# Altium schematic sheet uController.SchDoc(uController)
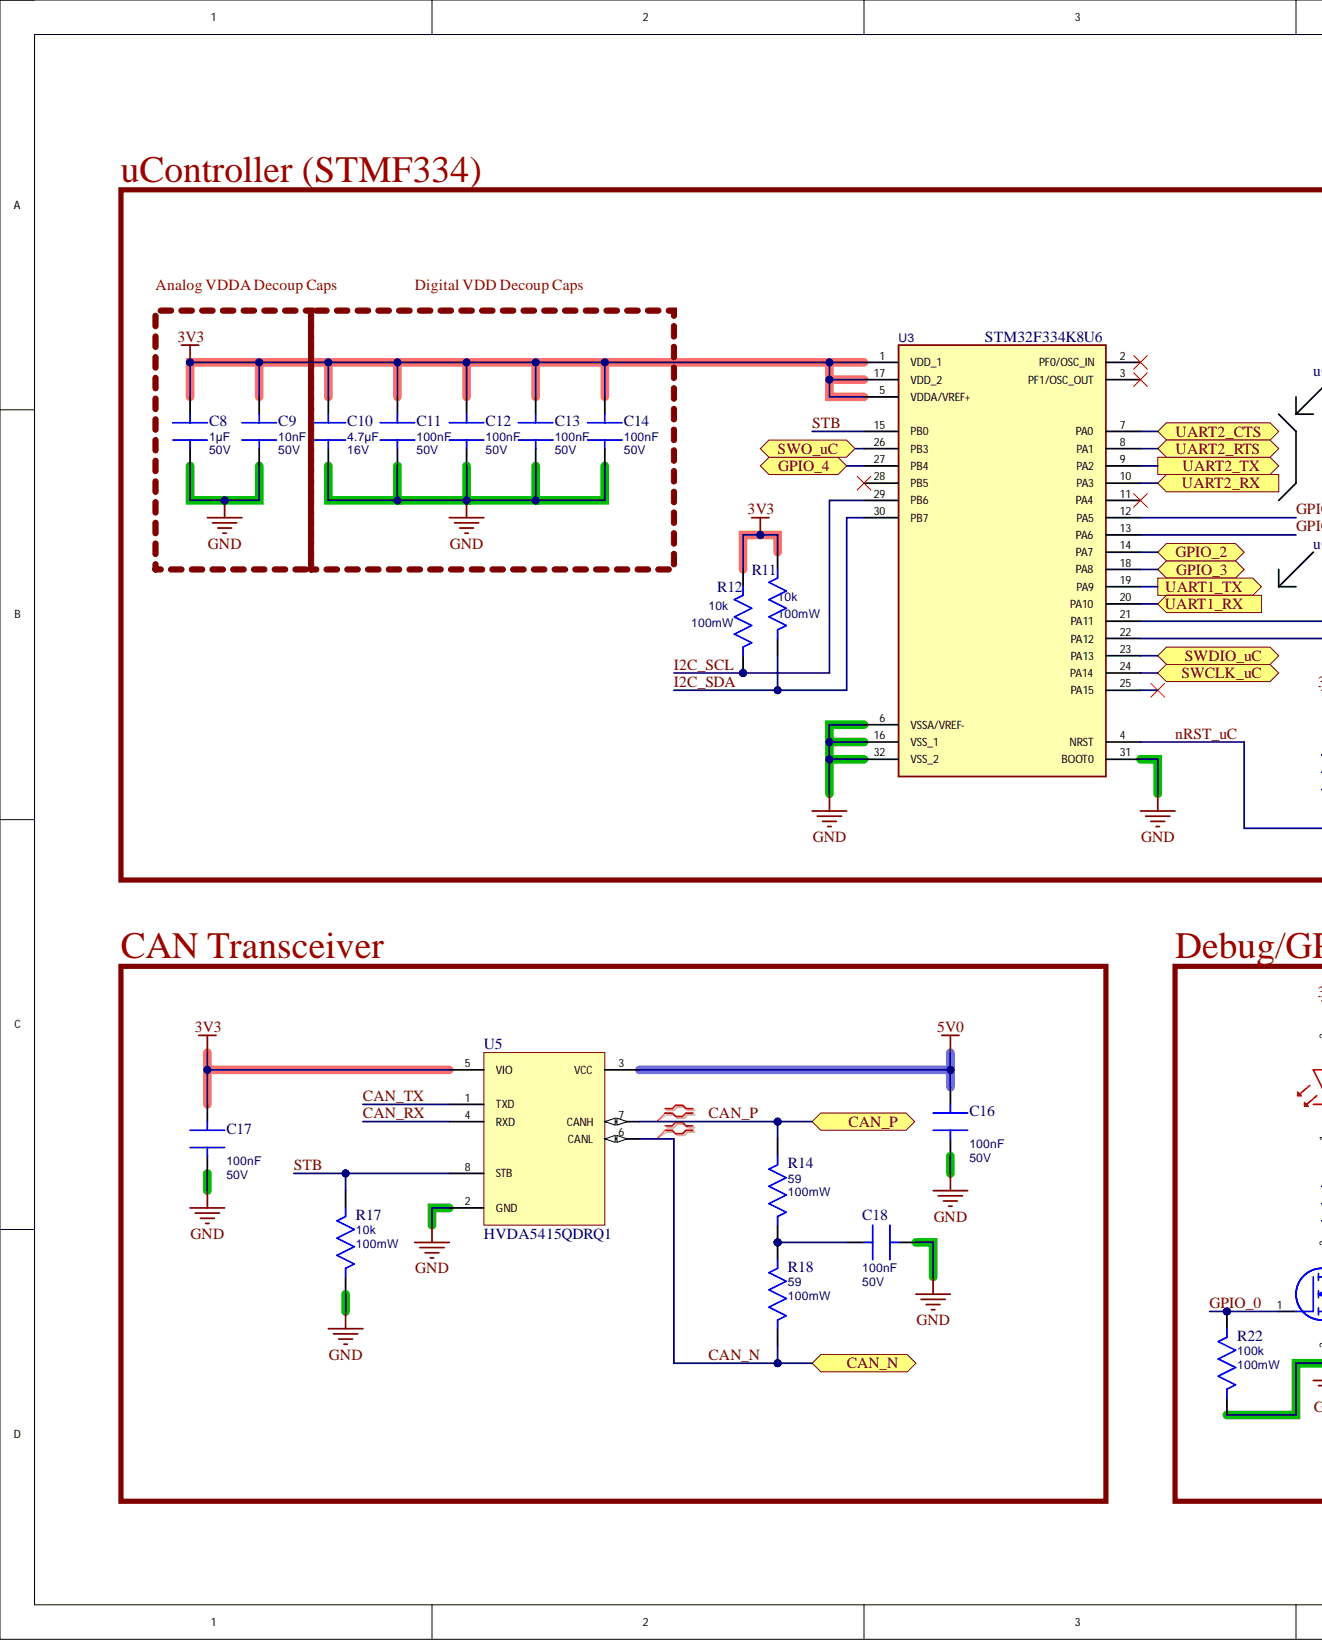
[diagram p0: uController.SchDoc, left]
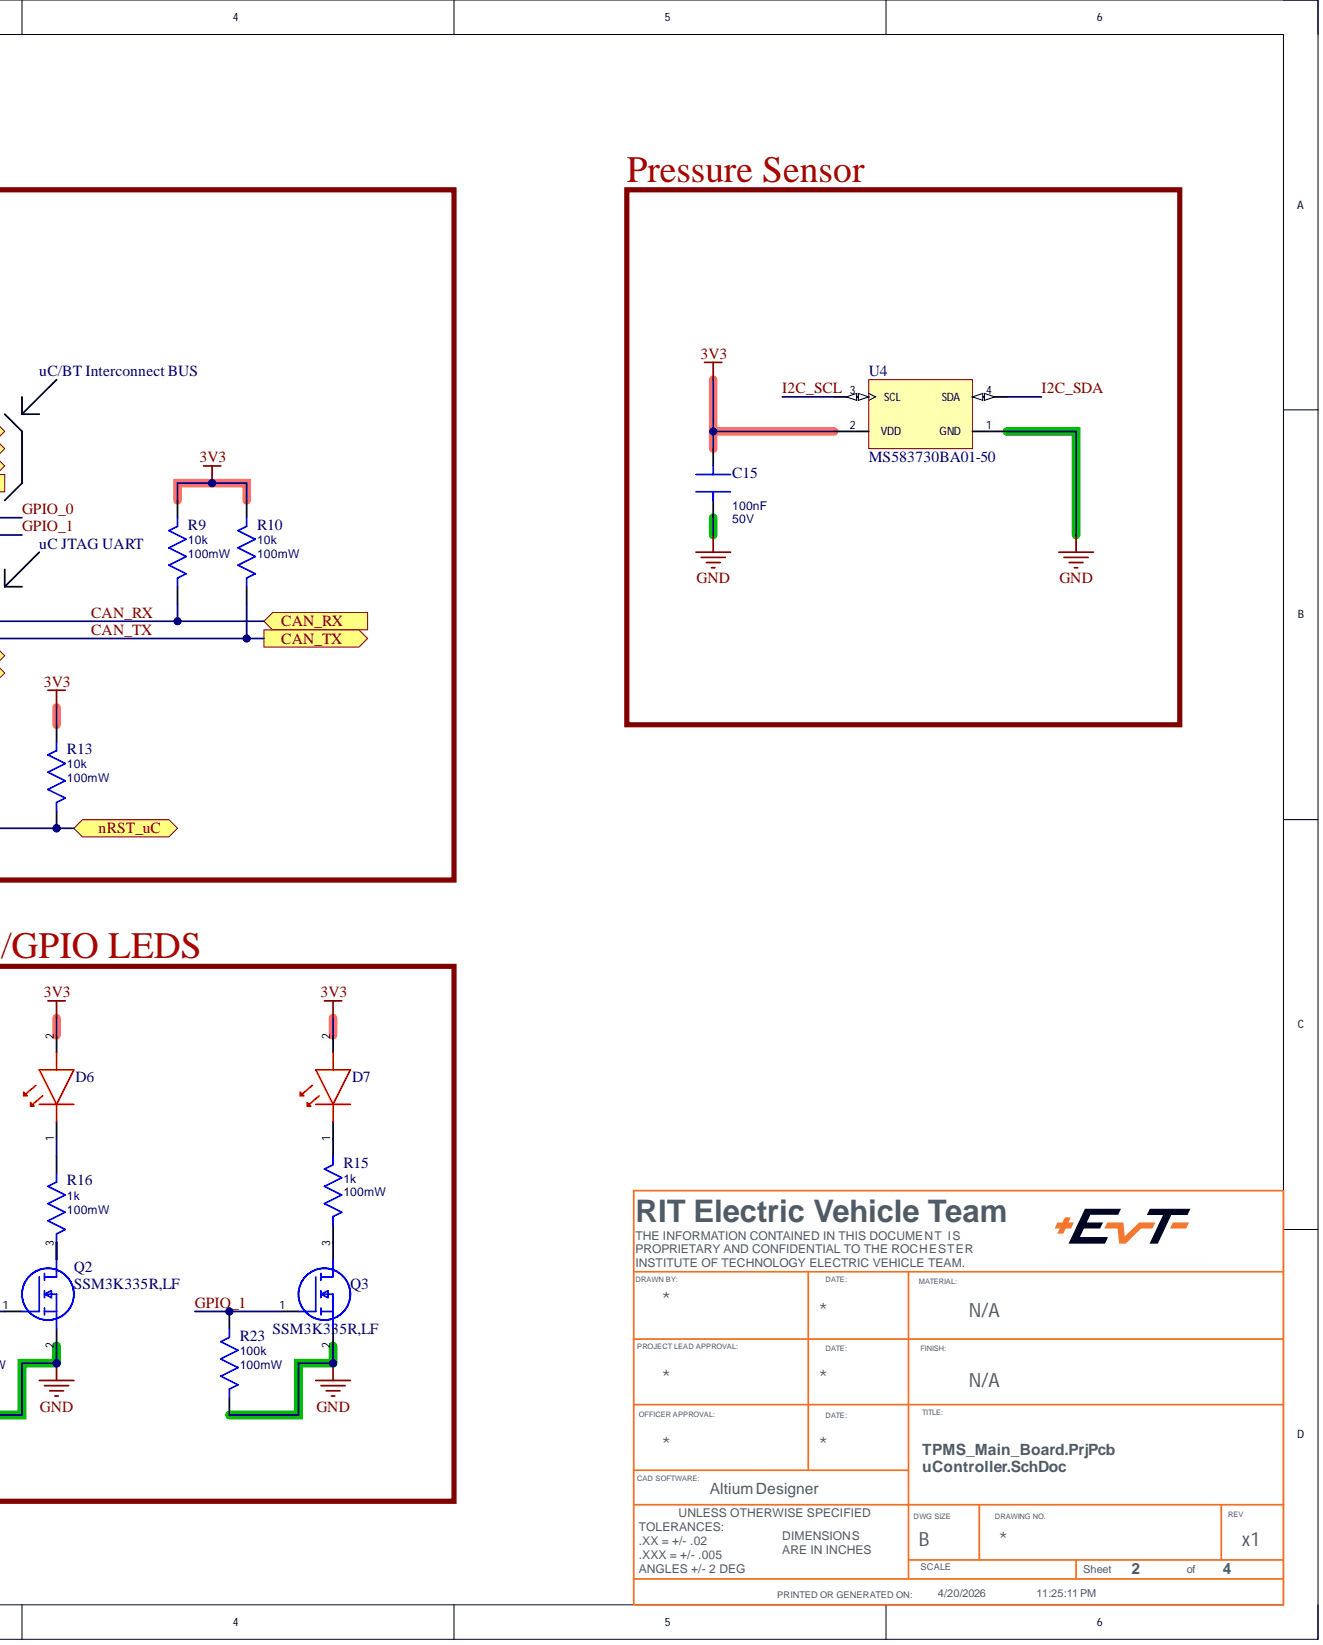
[diagram p1: uController.SchDoc, right]

## Parts
C8  Capacitance: 1µF  Voltage Rating: 50V  (hidden comment: CAP 1U0 50V X5R 10% 0603)
C9  Capacitance: 10nF  Voltage Rating: 50V  (hidden comment: CAP 10nF 50V X7R 10% 0603)
C10  Capacitance: 4.7µF  Voltage Rating: 16V  (hidden comment: CAP 4U7 16V X5R 10% 0603)
C11  Capacitance: 100nF  Voltage Rating: 50V  (hidden comment: CAP 100nF 50V X7R 10% 0603 CAP)
C12  Capacitance: 100nF  Voltage Rating: 50V  (hidden comment: CAP 100nF 50V X7R 10% 0603 CAP)
C13  Capacitance: 100nF  Voltage Rating: 50V  (hidden comment: CAP 100nF 50V X7R 10% 0603 CAP)
C14  Capacitance: 100nF  Voltage Rating: 50V  (hidden comment: CAP 100nF 50V X7R 10% 0603 CAP)
C15  Capacitance: 100nF  Voltage Rating: 50V  (hidden comment: CAP 100nF 50V X7R 10% 0603 CAP)
C16  Capacitance: 100nF  Voltage Rating: 50V  (hidden comment: CAP 100nF 50V X7R 10% 0603 CAP)
C17  Capacitance: 100nF  Voltage Rating: 50V  (hidden comment: CAP 100nF 50V X7R 10% 0603 CAP)
C18  Capacitance: 100nF  Voltage Rating: 50V  (hidden comment: CAP 100nF 50V X7R 10% 0603 CAP)
D6  RED 0603 LED  (value not drawn)
D7  RED 0603 LED  (value not drawn)
Q2  SSM3K335R,LF
Q3  SSM3K335R,LF
R9  Power Rating: 100mW  Resistance: 10k  (hidden comment: RES 10k0 100mW 1%)
R10  Power Rating: 100mW  Resistance: 10k  (hidden comment: RES 10k0 100mW 1%)
R11  Power Rating: 100mW  Resistance: 10k  (hidden comment: RES 10k 100m 0.1% 0603s)
R12  Power Rating: 100mW  Resistance: 10k  (hidden comment: RES 10k 100m 0.1% 0603s)
R13  Power Rating: 100mW  Resistance: 10k  (hidden comment: RES 10k0 100mW 1%)
R14  Power Rating: 100mW  (hidden comment: RES 59R 100mW 1% 0603)
R15  Power Rating: 100mW  Resistance: 1k  (hidden comment: RES 1k 100m 0.1% 0603)
R16  Power Rating: 100mW  Resistance: 1k  (hidden comment: RES 1k 100m 0.1% 0603)
R17  Power Rating: 100mW  Resistance: 10k  (hidden comment: RES 10k0 100mW 1%)
R18  Power Rating: 100mW  (hidden comment: RES 59R 100mW 1% 0603)
R22  Power Rating: 100mW  Resistance: 100k  (hidden comment: RES 1K0 100mW 1%)
R23  Power Rating: 100mW  Resistance: 100k  (hidden comment: RES 1K0 100mW 1%)
U3  STM32F334K8U6
U4  MS583730BA01-50
U5  HVDA5415QDRQ1

## Ports
CAN_N, CAN_P, CAN_RX, CAN_TX, GPIO_2, GPIO_3, GPIO_4, nRST_uC, SWCLK_uC, SWDIO_uC, SWO_uC, UART1_RX, UART1_TX, UART2_CTS, UART2_RTS, UART2_RX, UART2_TX

## Nets
3V3: C8.1 C9.1 C10.1 C11.1 C12.1 C13.1 C14.1 C15.1 C17.1 D6.2 D7.2 R9.2 R10.2 R11.1 R12.1 R13.2 U3.1(VDD_1) U3.5(VDDA/VREF+) U3.17(VDD_2) U4.2(VDD) U5.5(VIO)
5V0: C16.1 U5.3(VCC)
GND: C8.2 C9.2 C10.2 C11.2 C12.2 C13.2 C14.2 C15.2 C16.2 C17.2 C18.2 Q2.2 Q3.2 R17.2 R22.2 R23.2 U3.6(VSSA/VREF-) U3.16(VSS_1) U3.31(BOOT0) U3.32(VSS_2) U4.1(GND) U5.2(GND)
CAN_N: R18.2 U5.6(CANL) ; port CAN_N
CAN_P: R14.1 U5.7(CANH) ; port CAN_P
CAN_RX: R9.1 U3.21(PA11) U5.4(RXD) ; port CAN_RX
CAN_TX: R10.1 U3.22(PA12) U5.1(TXD) ; port CAN_TX
GPIO_0: Q2.1 R22.1 U3.12(PA5)
GPIO_1: Q3.1 R23.1 U3.13(PA6)
GPIO_2: U3.14(PA7) ; port GPIO_2
GPIO_3: U3.18(PA8) ; port GPIO_3
GPIO_4: U3.27(PB4) ; port GPIO_4
I2C_SCL: R12.2 U3.29(PB6) U4.3(SCL)
I2C_SDA: R11.2 U3.30(PB7) U4.4(SDA)
nRST_uC: R13.1 U3.4(NRST) ; port nRST_uC
STB: R17.1 U3.15(PB0) U5.8(STB)
SWCLK_uC: U3.24(PA14) ; port SWCLK_uC
SWDIO_uC: U3.23(PA13) ; port SWDIO_uC
SWO_uC: U3.26(PB3) ; port SWO_uC
UART1_RX: U3.20(PA10) ; port UART1_RX
UART1_TX: U3.19(PA9) ; port UART1_TX
UART2_CTS: U3.7(PA0) ; port UART2_CTS
UART2_RTS: U3.8(PA1) ; port UART2_RTS
UART2_RX: U3.10(PA3) ; port UART2_RX
UART2_TX: U3.9(PA2) ; port UART2_TX
NetC18_1: C18.1 R14.2 R18.1
NetD6_1: D6.1 R16.1
NetD7_1: D7.1 R15.1
NetQ2_3: Q2.3 R16.2
NetQ3_3: Q3.3 R15.2

Not connected: U3 2(PF0/OSC_IN) 3(PF1/OSC_OUT) 11(PA4) 25(PA15) 28(PB5)

## Library data of the parts
C8 = CMP-00418-4: 1uF 50V X5R ±10% 0603 Multilayer Ceramic Capacitors MLCC - SMD/SMT ROHS; MPN: CL10A105KB8NNNC; Polarized (Y/N): N; Component Kind: Standard; JLC Basic Part (Y/N): Y; JLC Part #: C15849; Package: 0603; Temperature Coefficient: X5R; Tolerance: 10%; Library Name: RIT-EVT-Vault
C9 = CMP-00414-5: 10nF 50V X7R ±10% 0603 Multilayer Ceramic Capacitors MLCC - SMD/SMT ROHS; MPN: 0603B103K500NT; Polarized (Y/N): N; Component Kind: Standard; JLC Basic Part (Y/N): Y; JLC Part #: C57112; Package: 0603; Temperature Coefficient: X7R; Tolerance: 10%; Library Name: RIT-EVT-Vault
C10 = CMP-00412-4: 16V 4.7uF X5R ±10% 0603 Multilayer Ceramic Capacitors MLCC - SMD/SMT ROHS; MPN: CL10A475KO8NNNC; Polarized (Y/N): N; Component Kind: Standard; JLC Basic Part (Y/N): Y; JLC Part #: C19666; Package: 0603; Temperature Coefficient: X5R; Tolerance: 10%; Library Name: RIT-EVT-Vault
C11-C14 C16-C18 = CMP-00408-13: 50V 100nF X7R ±10% 0603 Multilayer Ceramic Capacitors MLCC - SMD/SMT ROHS; MPN: CC0603KRX7R9BB104; Polarized (Y/N): N; Component Kind: Standard; JLC Basic Part (Y/N): Y; JLC Part #: C14663; Package: 0603; Temperature Coefficient: X7R; Tolerance: 10%; Library Name: RIT-EVT-Vault
C15 = CMP-00408-16: 50V 100nF X7R ±10% 0603 Multilayer Ceramic Capacitors MLCC - SMD/SMT ROHS; MPN: CC0603KRX7R9BB104; Polarized (Y/N): N; Component Kind: Standard; JLC Basic Part (Y/N): Y; JLC Part #: C14663; Package: 0603; Temperature Coefficient: X7R; Tolerance: 10%; Library Name: RIT-EVT-Vault
D6 D7 = CMP-00415-5: LED Low-Power Uni-Color Red 625nm 2-Pin Chip LED T/R; MPN: APT1608EC; Current Rating: 30mA; Forward Voltage: 2V; Lumosity: Remove; Reverse Breakdown Voltage: 5V; Wavelength: 617nm; Color: Red; Component Kind: Standard; JLC Basic Part (Y/N): N; JLCPCB Part #: C598355; Package: 0603; Library Name: RIT-EVT-Vault
Q2 Q3 = CMP-00489-2: -55℃~+150℃ 1 N-Channel 1W 2.5V@0.1mA 2.7nC@4.5V 20pF 30V 340pF@15V 38mΩ@10V 60pF 9A N-Channel SOT-23F MOSFETs ROHS; MPN: SSM3K335R,LF(T; Rated Drain/Collector Current: 6A; Component Kind: Standard; JLC Basic Part: N; JLCPCB Part: Y; JLCPCB Part #: C396057; Package: SOT-23F; Rated Drain-Source or Collector-Emitter Voltage: 30V; Transistor Type: N-Channel; Library Name: RIT-EVT-Vault
R9 R10 R13 = CMP-00407-4: -55℃~+155℃ 100mW 10kΩ 75V Thick Film Resistor ±1% ±100ppm/℃ 0603 Chip Resistor - Surface Mount ROHS; MPN: 0603WAF1002T5E; Polarized (Y/N): N; Component Kind: Standard; JLC Basic Part (Y/N): Y; JLCPCB Part #: C25804; Package: 0603; Tolerance: 1%; Voltage Rating: 75V; Library Name: RIT-EVT-Vault
R11 R12 = CMP-00540-2: -55℃~+155℃ 100mW 10kΩ 75V Thin Film Resistor ±0.1% ±25ppm/℃ 0603 Chip Resistor - Surface Mount ROHS; MPN: RT0603BRD0710KL; Polarized (Y/N): N; Component Kind: Standard; JLC Basic Part (Y/N): N; JLCPCB Part #: C95204; Package: 0603; Tolerance: 0.1%; Voltage Rating: 75V; Library Name: RIT-EVT-Vault
R14 R18 = CMP-00543-3: Res Thick Film 0603 59 Ohm 1% 0.1W(1/10W) ±100ppm/C Pad SMD T/R; MPN: ERJ-3EKF59R0V; Polarized (Y/N): N; Resistance: 59; Voltage Rating: 75V; Component Kind: Standard; JLC Basic Part (Y/N): N; JLCPCB Part #: C273801; Package: 0603; Tolerance: 1%; Library Name: RIT-EVT-Vault
R15 R16 = CMP-00544-3: -55℃~+155℃ 100mW 1kΩ 75V Thin Film Resistor ±0.1% ±25ppm/℃ 0603 Chip Resistor - Surface Mount ROHS; MPN: RT0603BRD071KL; Polarized (Y/N): N; Component Kind: Standard; JLC Basic Part (Y/N): N; JLCPCB Part #: C110776; Package: 0603; Tolerance: 0.1%; Voltage Rating: 75V; Library Name: RIT-EVT-Vault
R17 = CMP-00407-6: -55℃~+155℃ 100mW 10kΩ 75V Thick Film Resistor ±1% ±100ppm/℃ 0603 Chip Resistor - Surface Mount ROHS; MPN: RC0603FR-0710KL; Polarized (Y/N): N; Component Kind: Standard; JLC Basic Part (Y/N): Y; JLCPCB Part #: C25804; Package: 0603; Tolerance: 1%; Voltage Rating: 75V; Library Name: RIT-EVT-Vault
R22 R23 = CMP-00410-17: 100mW Thick Film Resistors 75V ±100ppm/℃ ±1% 1kΩ 0603 Chip Resistor - Surface Mount ROHS; MPN: RMCF0603FT1K00; Polarized (Y/N): N; Component Kind: Standard; JLC Basic Part (Y/N): Y; JLCPCB Part #: C21190; Package: 0603; Tolerance: 1%; Voltage Rating: 75V; Library Name: RIT-EVT-Vault
U3 = CMP-00411-2: MCU 32-Bit ARM Cortex-M4F RISC 64KB Flash 2V to 3.6V 32-Pin UFQFPN Tray; JLPCB Part #: C1341602; Component Kind: Standard; JLC Basic Part (Y/N): N; MPN: STM32F334K8U6; Package: UFQFPN-32(5x5); Library Name: RIT-EVT-Vault
U4 = CMP-00459-1: Absolute Pressure Sensor (Altimeter), Surface Mount, 3.3 x 3.3 x 2.75; JLPCB Part #: C2887941; Component Kind: Standard; JLC Basic Part (Y/N): N; MPN: MS583730BA01-50; Package: SMD-4P,3.3x3.3mm; Library Name: RIT-EVT-Vault
U5 = CMP-00421-3: Interface Circuit, 1-Trnsvr, PDSO8; JLPCB Part #: C2671489; Component Kind: Standard; JLC Basic Part (Y/N): Y; MPN: HVDA5415QDRQ1; Package: SOIC-8; Library Name: RIT-EVT-Vault
